# Altium schematic sheet Voltage Dividers.SchDoc("Voltage Dividers")
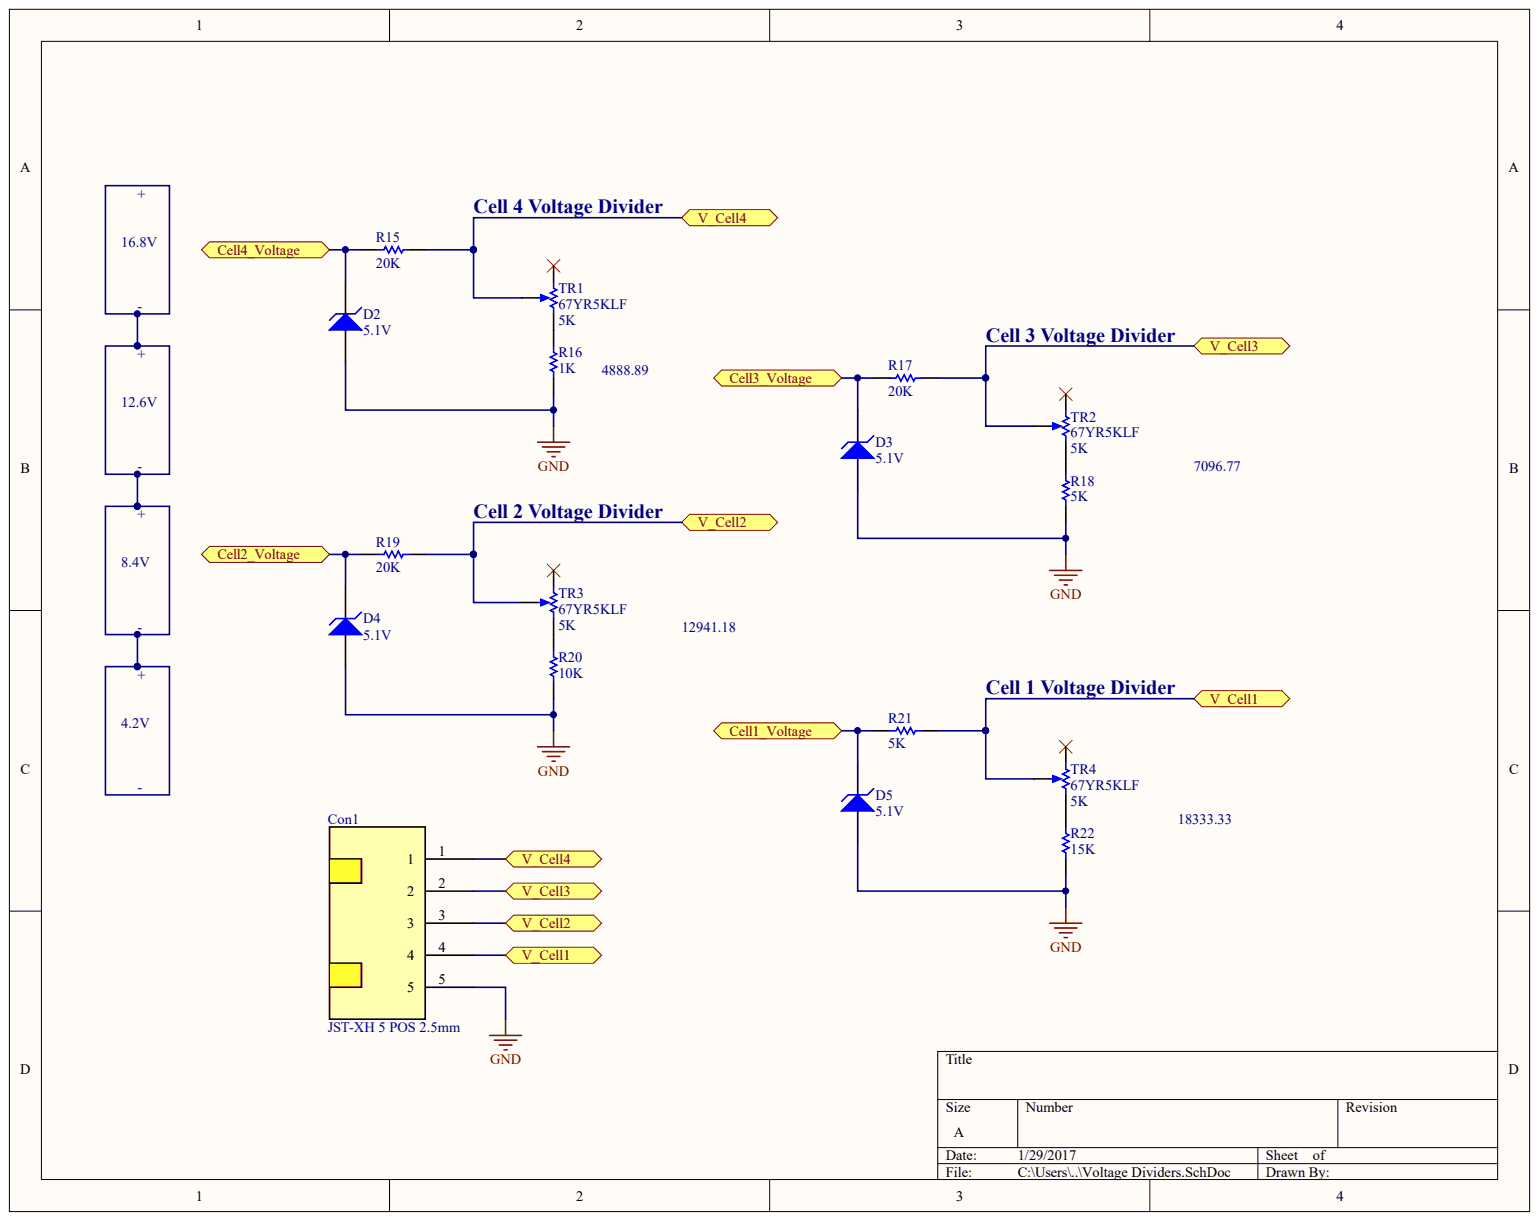
[diagram p0: Voltage Dividers.SchDoc]

## Parts
Con1  JST-XH 5 POS 2.5mm
D2  5.1V
D3  5.1V
D4  5.1V
D5  5.1V
R15  value 20K  (hidden comment: Res 0805)
R16  value 1K  (hidden comment: Res 0805)
R17  value 20K  (hidden comment: Res 0805)
R18  value 5K  (hidden comment: Res 0805)
R19  value 20K  (hidden comment: Res 0805)
R20  value 10K  (hidden comment: Res 0805)
R21  value 5K  (hidden comment: Res 0805)
R22  value 15K  (hidden comment: Res 0805)
TR1  67YR5KLF  value 5K
TR2  67YR5KLF  value 5K
TR3  67YR5KLF  value 5K
TR4  67YR5KLF  value 5K

## Ports
CELL1_VOLTAGE, CELL2_VOLTAGE, CELL3_VOLTAGE, CELL4_VOLTAGE, V_CELL1, V_CELL2, V_CELL3, V_CELL4

## Nets
CELL1_VOLTAGE: D5.2 R21.1 ; port CELL1_VOLTAGE
CELL2_VOLTAGE: D4.2 R19.1 ; port CELL2_VOLTAGE
CELL3_VOLTAGE: D3.2 R17.1 ; port CELL3_VOLTAGE
CELL4_VOLTAGE: D2.2 R15.1 ; port CELL4_VOLTAGE
GND: Con1.5 D2.1 D3.1 D4.1 D5.1 R16.1 R18.1 R20.1 R22.1
V_CELL1: Con1.4 R21.2 TR4.3 ; port V_CELL1
V_CELL2: Con1.3 R19.2 TR3.3 ; port V_CELL2
V_CELL3: Con1.2 R17.2 TR2.3 ; port V_CELL3
V_CELL4: Con1.1 R15.2 TR1.3 ; port V_CELL4
NetR16_2: R16.2 TR1.2
NetR18_2: R18.2 TR2.2
NetR20_2: R20.2 TR3.2
NetR22_2: R22.2 TR4.2
N00101:

Not connected: TR1 1; TR2 1; TR3 1; TR4 1
